# Altium schematic sheet Power.SchDoc(Power)
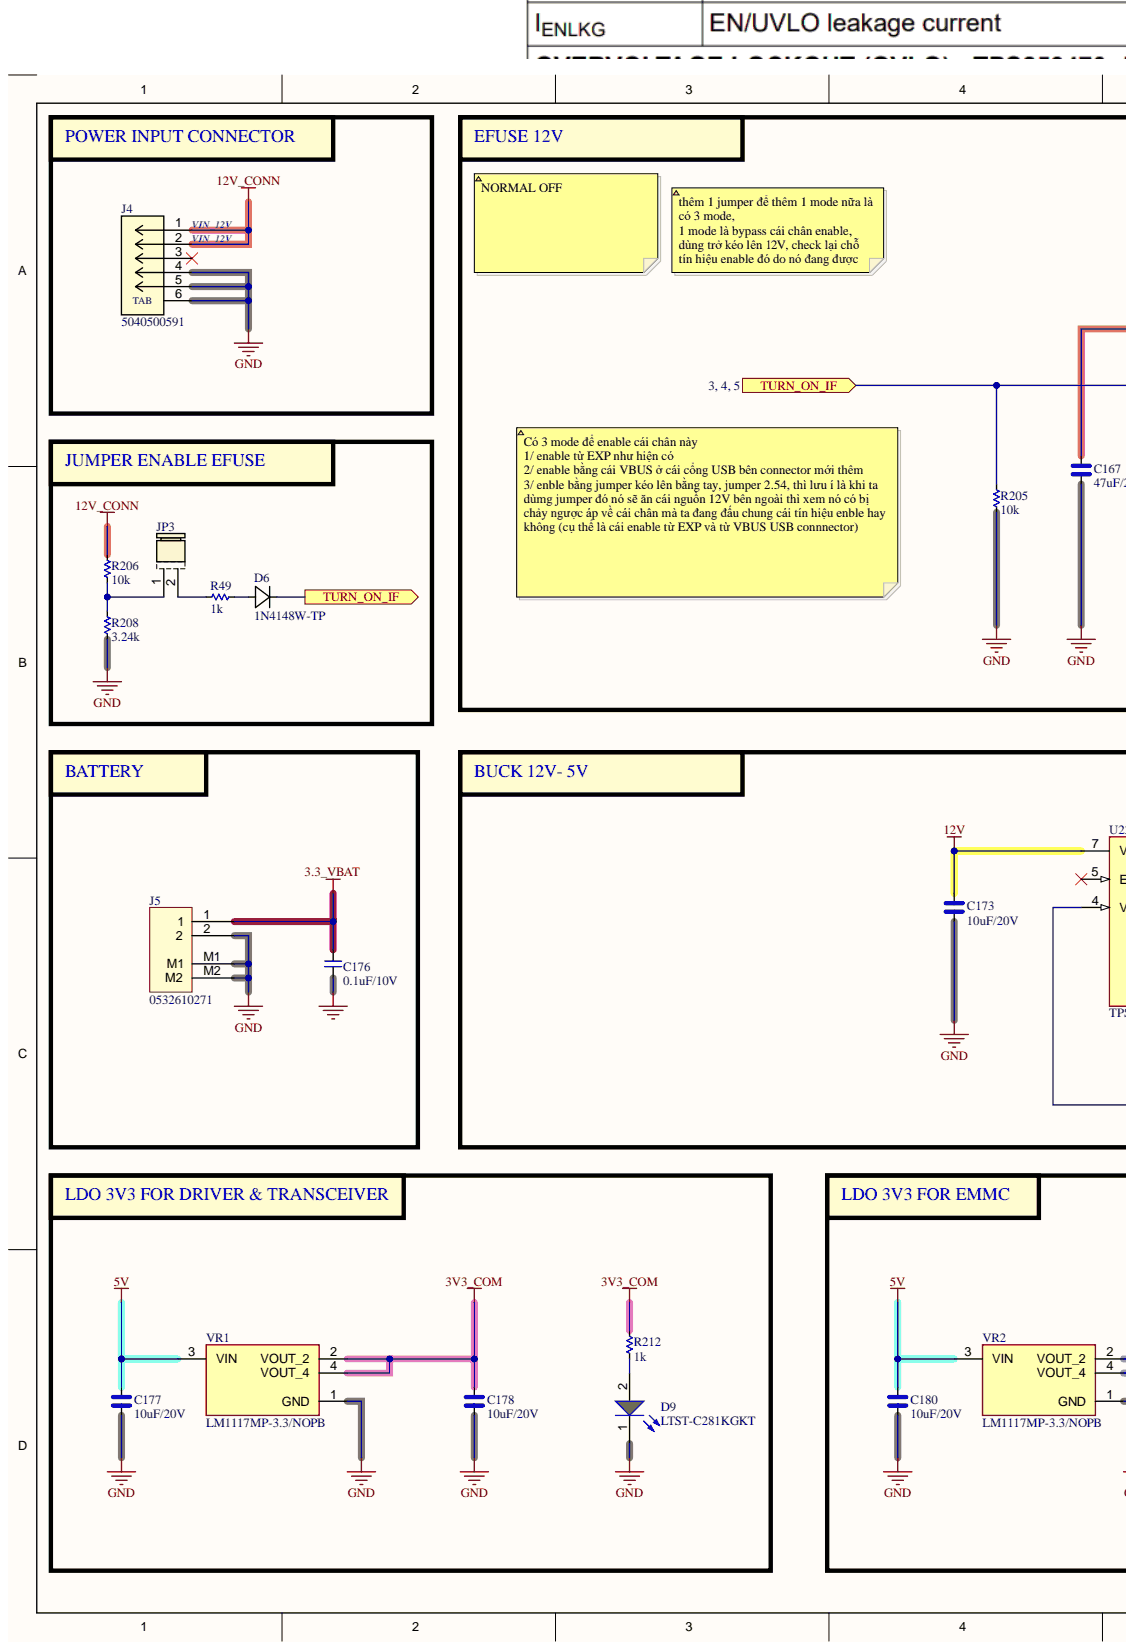
[diagram p0: Power.SchDoc, left]
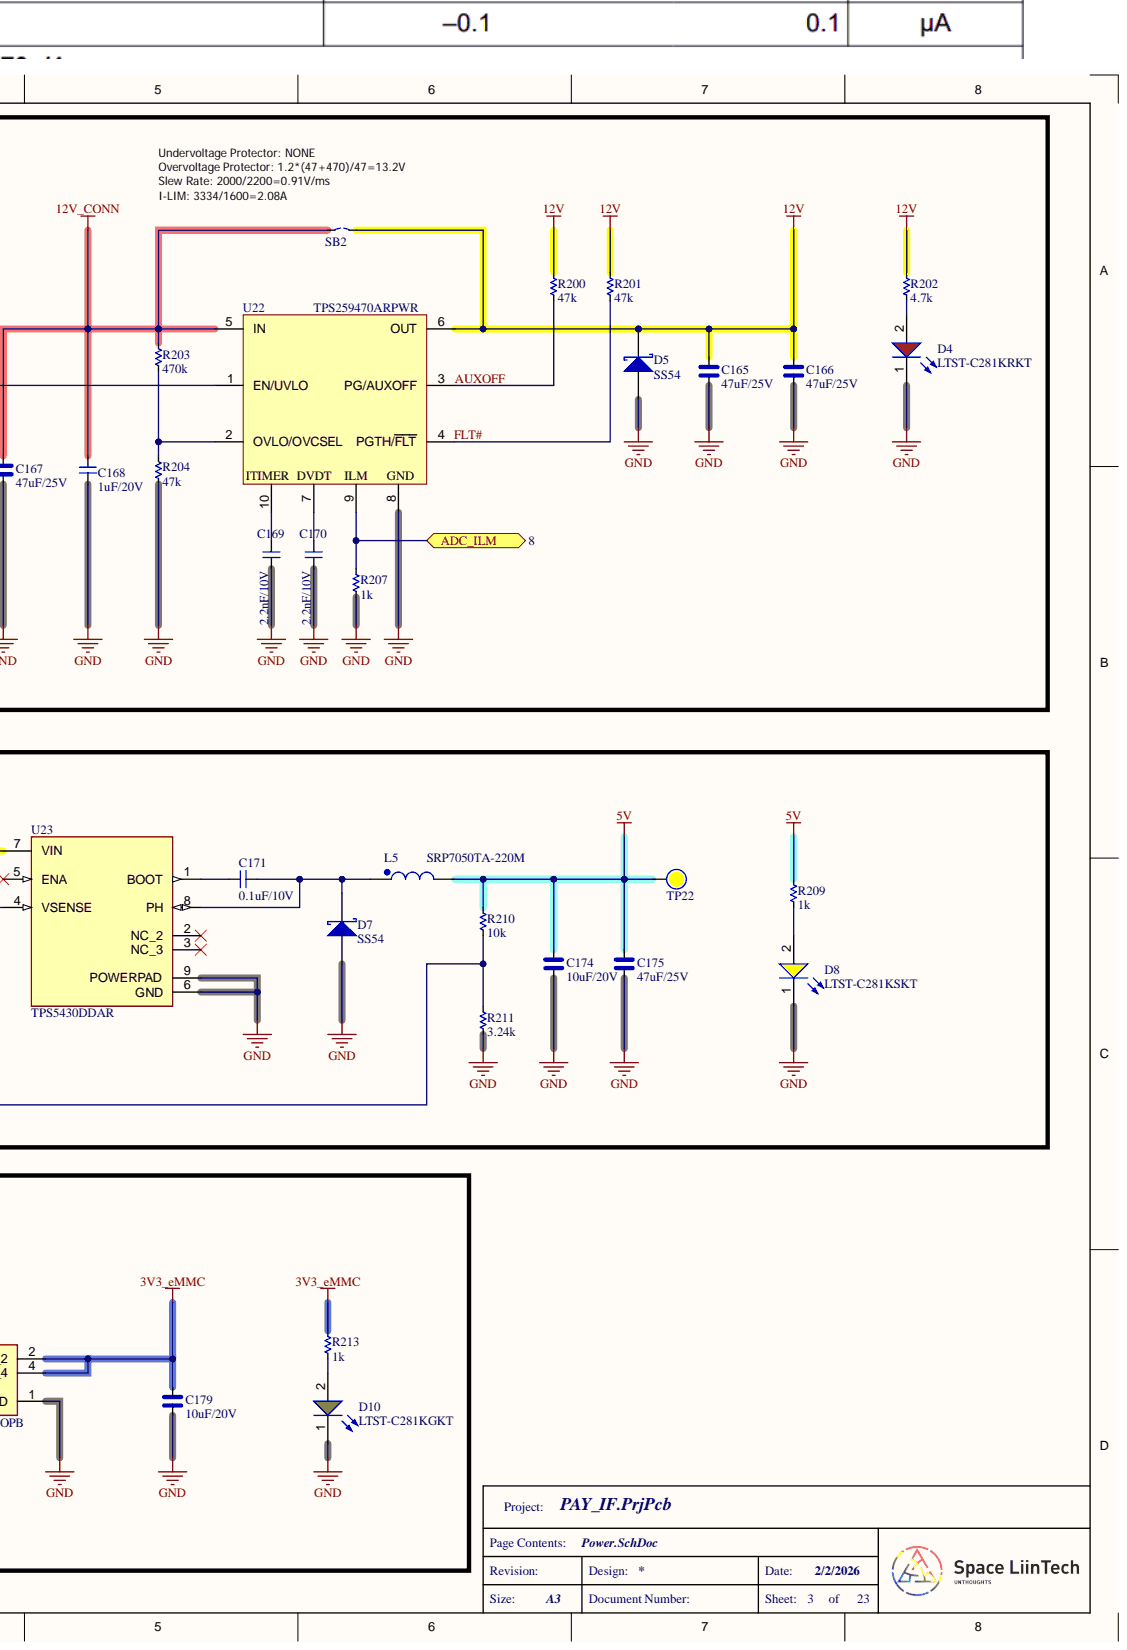
[diagram p1: Power.SchDoc, right]

## Parts
C165  47uF/25V  [CAP_C_3225X80]
C166  47uF/25V  [CAP_C_3225X80]
C167  47uF/25V  [CAP_C_3225X80]
C168  1uF/20V  [CAP_C_1005X50_L]
C169  2.2nF/10V  [CAP_C_1005X50_L]
C170  2.2nF/10V  [CAP_C_1005X50_L]
C171  0.1uF/10V  [CAP_C_1608X80_L]
C173  10uF/20V  [CAP_C_2012X110]
C174  10uF/20V  [CAP_C_2012X110]
C175  47uF/25V  [CAP_C_3225X80]
C176  0.1uF/10V  [CAP_C_1005X50_L]
C177  10uF/20V  [CAP_C_2012X110]
C178  10uF/20V  [CAP_C_2012X110]
C179  10uF/20V  [CAP_C_2012X110]
C180  10uF/20V  [CAP_C_2012X110]
D4  LTST-C281KRKT  [LED_0402]
D5  SS54  [DO-214AC_SMA]
D6  1N4148W-TP  [SOD123_MCC]
D7  SS54  [DO-214AC_SMA]
D8  LTST-C281KSKT  [LED_0402]
D9  LTST-C281KGKT  [LED_0402]
D10  LTST-C281KGKT  [LED_0402]
J4  5040500591  [5040500591]
J5  0532610271  [MOLEX_0532610271]
JP3  JUMPER_254P_NOPLACE  [JUMPER_254P_2X1_ANGLE]  (value not drawn)
L5  SRP7050TA-220M  [SRP7050TA-220M]
R49  1k  [RES_C_1005x30_L]
R200  47k  [RES_C_1005x30_L]
R201  47k  [RES_C_1005x30_L]
R202  4.7k  [RES_C_1005x30_L]
R203  470k  [RES_C_1005x30_L]
R204  47k  [RES_C_1005x30_L]
R205  10k  [RES_C_1005x30_L]
R206  10k  [RES_C_1005x30_L]
R207  1k  [RES_C_1005x30_L]
R208  3.24k  [RES_C_1005x30_L]
R209  1k  [RES_C_1005x30_L]
R210  10k  [RES_C_1005x30_L]
R211  3.24k  [RES_C_1005x30_L]
R212  1k  [RES_C_1005x30_L]
R213  1k  [RES_C_1005x30_L]
SB2  JUMPER  [JUMPER_1608]  (value not drawn)
TP22  (no value)  [Test point]  (no BOM)
U22  TPS259470ARPWR  [VQFN-HR-10]
U23  TPS5430DDAR  [CONV_TPS5430DDAR]
VR1  LM1117MP-3.3/NOPB  [VREG_LM1117MP-3.3/NOPB]
VR2  LM1117MP-3.3/NOPB  [VREG_LM1117MP-3.3/NOPB]

## Ports
ADC_ILM, TURN_ON_IF

## Nets
3.3_VBAT: C176.1 J5.1
3V3_COM: C178.2 R212.2 VR1.2(VOUT_2) VR1.4(VOUT_4)
3V3_eMMC: C179.2 R213.2 VR2.2(VOUT_2) VR2.4(VOUT_4)
5V: C174.2 C175.2 C177.2 C180.2 L5.2 R209.2 R210.1 TP22.0 VR1.3(VIN) VR2.3(VIN)
12V: C165.2 C166.2 C173.2 D5.1 R200.2 R201.2 R202.2 SB2.2 U22.6(OUT) U23.7(VIN)
12V_CONN: C167.2 C168.2 J4.1 J4.2 R203.1 R206.2 SB2.1 U22.5(IN)
GND: C165.1 C166.1 C167.1 C168.1 C169.1 C170.1 C173.1 C174.1 C175.1 C176.2 C177.1 C178.1 C179.1 C180.1 D4.1 D5.2 D7.2 D8.1 D9.1 D10.1 J4.4 J4.5 J4.6(TAB) J5.2 J5.M1 J5.M2 R204.2 R205.2 R207.2 R208.2 R211.2 U22.8 U23.6(GND) U23.9(POWERPAD) VR1.1(GND) VR2.1(GND)
ADC_ILM: R207.1 U22.9 ; port ADC_ILM
AUXOFF: R200.1 U22.3(PG/AUXOFF)
FLT#: R201.1 U22.4(PGTH/FLT)
TURN_ON_IF: D6.1 R205.1 U22.1(EN/UVLO) ; port TURN_ON_IF
NetC169_2: C169.2 U22.10
NetC170_2: C170.2 U22.7
NetC171_1: C171.1 D7.1 L5.1 U23.8(PH)
NetC171_2: C171.2 U23.1(BOOT)
NetD4_2: D4.2 R202.1
NetD6_2: D6.2 R49.2
NetD8_2: D8.2 R209.1
NetD9_2: D9.2 R212.1
NetD10_2: D10.2 R213.1
NetJP3_1: JP3.1 R206.1 R208.1
NetJP3_2: JP3.2 R49.1
NetR203_2: R203.2 R204.1 U22.2(OVLO/OVCSEL)
NetR210_2: R210.2 R211.1 U23.4(VSENSE)

Not connected: J4 3; U23 2(NC_2) 3(NC_3) 5(ENA)

## Library data of the parts
C165-C167 C173-C175 C177-C180 = _CAP_C_High_2: CAP CHIP High Value; Component Kind: Standard; Library Name: IFBschelib.SchLib
C168-C171 C176 = _CAP_C_2: CAP CHIP; Component Kind: Standard; Library Name: IFBschelib.SchLib
D4 = LED_RED: LED RED CLEAR 0402 SMD; Component Kind: Standard; Library Name: IFBschelib.SchLib
D5 D7 = SS54: DIODE SCHOTTKY 40V 5A SMA; MPN: SS34; Solder: SMT; Value: SS34; Component Kind: Standard; Library Name: IFBschelib.SchLib
D6 = 1N4148W-TP: Description; Manufacturer_Name: MCC; Component Kind: Standard; Manufacturer_Part_Number: 1N4148W-TP; Copyright: Copyright (C) 2026 Ultra Librarian. All rights reserved.; Library Name: IFBschelib.SchLib
D8 = LED_YELLOW: LED YELLOW CLEAR 0402 SMD; Component Kind: Standard; Library Name: IFBschelib.SchLib
D9 D10 = LED_GREEN: LED GREEN CLEAR 0402 SMD; Component Kind: Standard; Library Name: IFBschelib.SchLib
J4 = 5040500591: 1.50mm Pitch, Pico-Lock PCB Header, Single Row, Right-Angle, Surface Mount, Gold (Au) Plating, Positive Lock, 5 Circuits, Tape and Reel; Manufacturer_Name: Molex Connector Corporation; Component Kind: Standard; Manufacturer_Part_Number: 5040500591; RefDes: RefDes; Type: Type; Copyright: Copyright (C) 2025 Ultra Librarian. All rights reserved.; Library Name: IFBschelib.SchLib
J5 = Header 2x1_2M: Component Kind: Standard; Library Name: IFBschelib.SchLib
L5 = _IND: FIXED IND 22UH 2.5A 170 MOHM SMD; Component Kind: Standard; Library Name: IFBschelib.SchLib
R49 R200-R213 = _RES_B_2: RES; Component Kind: Standard; Library Name: IFBschelib.SchLib
TP22 = Test Point: Solder: NF; Description: Test Point; Library Name: IFBschelib.SchLib
U23 = TPS5430DDAR: 5.5V to 36V Input, 3A, 500kHz Step-Down Converter; MF: Texas Instruments; Availability: In Stock; Check_prices: https://www.snapeda.com/parts/TPS5430DDAR/Texas+Instruments/view-part/?ref=eda; Component Kind: Standard; MP: TPS5430DDAR; Package: HSOIC-8 Texas Instruments; Price: None; Purchase-URL: https://pricing.snapeda.com/search/part/TPS5430DDAR/?ref=eda; SnapEDA_Link: https://www.snapeda.com/parts/TPS5430DDAR/Texas+Instruments/view-part/?ref=snap; Library Name: IFBschelib.SchLib
VR1 VR2 = LM1117MP-3.3/NOPB: 800-mA 15-V linear voltage regulator; MF: Texas Instruments; Check_prices: https://www.snapeda.com/parts/LM1117MP-3.3/NOPB/Texas+Instruments/view-part/?ref=eda; Component Kind: Standard; MP: LM1117MP-3.3/NOPB; Package: SOT-223-4 Texas Instruments; SnapEDA_Link: https://www.snapeda.com/parts/LM1117MP-3.3/NOPB/Texas+Instruments/view-part/?ref=snap; Library Name: IFBschelib.SchLib
JP3 = JUMPER_254P_NOPLACE: Component Kind: Standard; Library Name: IFBschelib.SchLib
SB2 = JUMPER: Component Kind: Standard; Library Name: IFBschelib.SchLib
U22 = TPS259470ARPWR: Component Kind: Standard; Library Name: IFBschelib.SchLib
